# Altium schematic sheet PWR.SchDoc
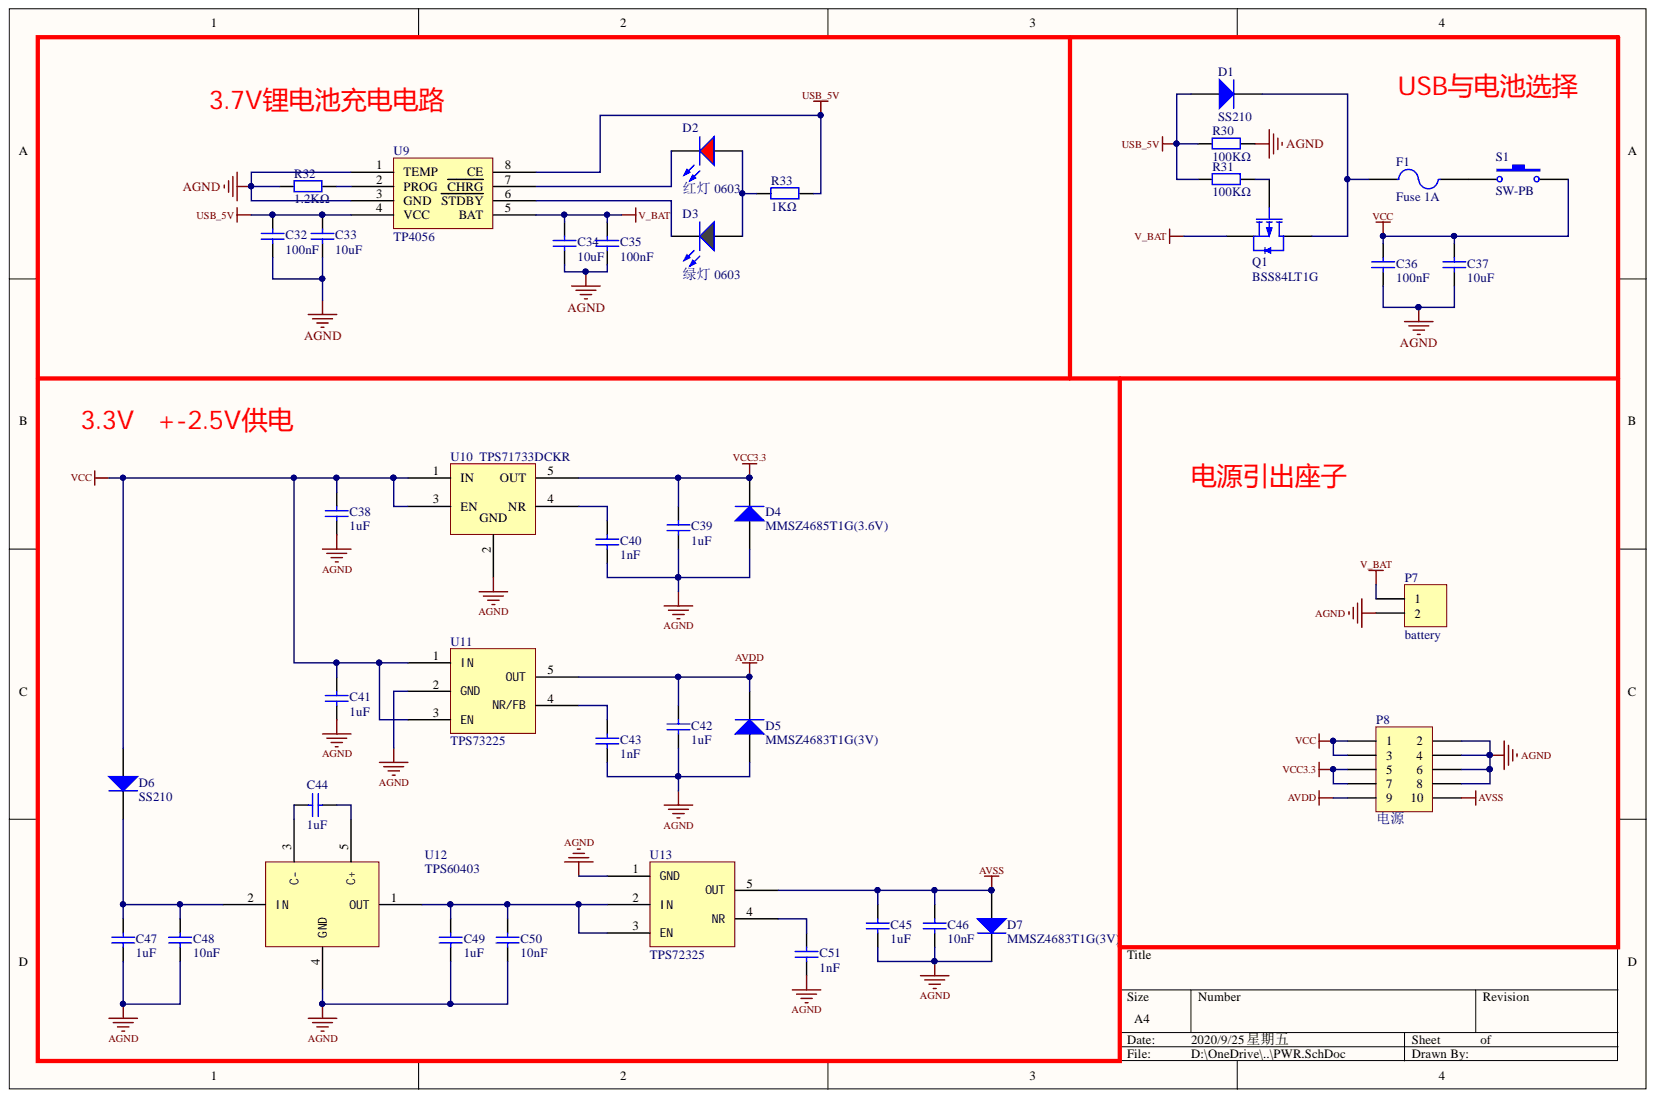
[diagram p0: PWR.SchDoc]

## Parts
C32  100nF  [0402_C]
C33  10uF  [0402_C]
C34  10uF  [0402_C]
C35  100nF  [0402_C]
C36  100nF  [0402_C]
C37  10uF  [0402_C]
C38  1uF  [0402_C]
C39  1uF  [0402_C]
C40  1nF  [0402_C]
C41  1uF  [0402_C]
C42  1uF  [0402_C]
C43  1nF  [0402_C]
C44  1uF  [0402_C]
C45  1uF  [0402_C]
C46  10nF  [0402_C]
C47  1uF  [0402_C]
C48  10nF  [0402_C]
C49  1uF  [0402_C]
C50  10nF  [0402_C]
C51  1nF  [0402_C]
D1  SS210  [SMA(DO-214AC)_S1]
D2  红灯 0603  Package: 0603  [0603_LED_S1]
D3  绿灯 0603  Package: 0603  [0603_LED_S1]
D4  MMSZ4685T1G(3.6V)  [SOD-123]
D5  MMSZ4683T1G(3V)  [SOD-123]
D6  SS210  [SMA(DO-214AC)_S1]
D7  MMSZ4683T1G(3V)  [SOD-123]
F1  Fuse 1A  [0805_R]
P7  battery  [HDR1X2]
P8  电源  [HDR2X5]
Q1  BSS84LT1G  [SOT-23(SOT-23-3)]
R30  100KΩ  [0402_R]
R31  100KΩ  [0402_R]
R32  1.2KΩ  [0402_R]
R33  1KΩ  [0402_R]
S1  SW-PB  [KEY_5.8*5.8]
U9  TP4056  [SOP-8_EP_150mil]
U10  TPS71733DCKR  [SC-70-5]
U11  TPS73225  [SOT-23-5]
U12  TPS60403  [SOT-23-5]
U13  TPS72325  [SOT-23-5]

## Nets
AGND: C32.1 C33.1 C34.1 C35.1 C36.1 C37.1 C38.1 C39.1 C40.1 C41.1 C42.1 C43.1 C45.2 C46.1 C47.2 C48.1 C49.2 C50.1 C51.1 D4.1 D5.1 D7.2 P7.2 P8.2 P8.4 P8.6 P8.8 R30.2 R32.1 U9.1(TEMP) U9.3(GND) U10.2 U11.2(GND) U12.4(GND) U13.1(GND)
AVDD: C42.2 D5.2 P8.9 U11.5(OUT)
AVSS: C45.1 C46.2 D7.1 P8.10 U13.5(OUT)
USB_5V: C32.2 C33.2 D1.1 R30.1 R31.1 R33.2 U9.4(VCC) U9.8(CE)
V_BAT: C34.2 C35.2 P7.1 Q1.2 U9.5(BAT)
VCC: C36.2 C37.2 C38.2 C41.2 D6.1 P8.1 P8.3 S1.2 U10.1(IN) U10.3(EN) U11.1(IN) U11.3(EN)
VCC3.3: C39.2 D4.2 P8.5 P8.7 U10.5(OUT)
NetC40_2: C40.2 U10.4(NR)
NetC43_2: C43.2 U11.4(NR/FB)
NetC44_1: C44.1 U12.5(C+)
NetC44_2: C44.2 U12.3(C-)
NetC47_1: C47.1 C48.2 D6.2 U12.2(IN)
NetC49_1: C49.1 C50.2 U12.1(OUT) U13.2(IN) U13.3(EN)
NetC51_2: C51.2 U13.4(NR)
NetD1_2: D1.2 F1.1 Q1.3
NetD2_A: D2.A D3.A R33.1
NetD2_K: D2.K U9.7(CHRG)
NetD3_K: D3.K U9.6(STDBY)
NetF1_2: F1.2 S1.1
NetQ1_1: Q1.1 R31.2
NetR32_2: R32.2 U9.2(PROG)

## Library data of the parts
C32 C35 C36 = 0402 100nF (104) 10% 16V: 贴片电容; Component Kind: Standard; ComponentLink1Description: 供应商链接; ComponentLink1URL: http://www.szlcsc.com/product/details_1877.html; Library Name: Miscellaneous Devices LC.IntLib; Package: 0402; Supplier: LC; SuppliersPartNumber: C1525
C33 C34 C37 = 0402 10uF (106) 20% 6.3V: 贴片电容; Component Kind: Standard; ComponentLink1Description: 供应商链接; ComponentLink1URL: http://www.szlcsc.com/product/details_16204.html; Library Name: Miscellaneous Devices LC.IntLib; Package: 0402; Supplier: LC; SuppliersPartNumber: C15525
C38 C39 C41 C42 C44 C45 C47 C49 = 0603 1uF (105) 10% 50V: 贴片电容; Component Kind: Standard; ComponentLink1Description: 供应商链接; ComponentLink1URL: http://www.szlcsc.com/product/details_16531.html; Library Name: Miscellaneous Devices LC.IntLib; Package: 0603; Supplier: LC; SuppliersPartNumber: C15849
C40 C43 C51 = 0603 1nF (102) 10% 50V: 贴片电容; Component Kind: Standard; ComponentLink1Description: 供应商链接; ComponentLink1URL: http://www.szlcsc.com/product/details_1940.html; Library Name: Miscellaneous Devices LC.IntLib; Package: 0603; Supplier: LC; SuppliersPartNumber: C1588
C46 C48 C50 = 0603 10nF (103) 10% 50V: 贴片电容; Component Kind: Standard; ComponentLink1Description: 供应商链接; ComponentLink1URL: http://www.szlcsc.com/product/details_58143.html; Library Name: Miscellaneous Devices LC.IntLib; Package: 0603; Supplier: LC; SuppliersPartNumber: C57112
D1 D6 = SMA(DO-214AC) SS210: 二极管; Component Kind: Standard; ComponentLink1Description: 供应商链接; ComponentLink1URL: http://www.szlcsc.com/product/details_15669.html; Library Name: Miscellaneous Devices LC.IntLib; Package: SMA(DO-214AC); Supplier: LC; SuppliersPartNumber: C14996
D2 = 0603 红灯: 发光二极管; Component Kind: Standard; ComponentLink1Description: 供应商链接; ComponentLink1URL: http://www.szlcsc.com/product/details_2659.html; Library Name: Miscellaneous Devices LC.IntLib; Supplier: LC; SuppliersPartNumber: C2286
D3 = 0603 绿灯: 发光二极管; Component Kind: Standard; ComponentLink1Description: 供应商链接; ComponentLink1URL: http://www.szlcsc.com/product/details_73152.html; Library Name: Miscellaneous Devices LC.IntLib; Supplier: LC; SuppliersPartNumber: C72043
D4 D5 D7 = SOD-123 B5819W  SL: 肖特基二极管; Component Kind: Standard; ComponentLink1Description: 供应商链接; ComponentLink1URL: http://www.szlcsc.com/product/details_9093.html; Library Name: Miscellaneous Devices LC.IntLib; Package: SOD-123; Supplier: LC; SuppliersPartNumber: C8598
F1 = Fuse 2: Fuse; Component Kind: Standard; LatestRevisionDate: 17-Jul-2002; LatestRevisionNote: Re-released for DXP Platform.; Library Name: Miscellaneous Devices.IntLib; PackageDescription: Thru-Hole; 2 Leads; PackageReference: PIN-W2/E2.8; Published: 8-Jun-2000; Simulation: FUSE; Publisher: Altium Limited
P7 = Header 2: Header, 2-Pin; Component Kind: Standard; LatestRevisionDate: 17-Jul-2002; LatestRevisionNote: Re-released for DXP Platform.; Library Name: Miscellaneous Connectors.IntLib; Signal Integrity: Connector; Publisher: Altium Limited
P8 = Header 5X2: Header, 5-Pin, Dual row; Component Kind: Standard; LatestRevisionDate: 17-Jul-2002; LatestRevisionNote: Re-released for DXP Platform.; Library Name: Miscellaneous Connectors.IntLib; Signal Integrity: Connector; Publisher: Altium Limited
Q1 = BSS84LT1G: MOS(场效应管); Component Kind: Standard; ComponentLink1Description: 供应商链接; ComponentLink1URL: http://www.szlcsc.com/product/details_8986.html; Library Name: Miscellaneous Devices LC.IntLib; Package: SOT-23(SOT-23-3); Supplier: LC; SuppliersPartNumber: C8492
R30 R31 = 0402 100KΩ (1003) 1%: 贴片电阻; Component Kind: Standard; ComponentLink1Description: 供应商链接; ComponentLink1URL: http://www.szlcsc.com/product/details_26484.html; Library Name: Miscellaneous Devices LC.IntLib; Package: 0402; Supplier: LC; SuppliersPartNumber: C25741
R32 = 0402 1.2KΩ (1201) 1%: 贴片电阻; Component Kind: Standard; ComponentLink1Description: 供应商链接; ComponentLink1URL: http://www.szlcsc.com/product/details_26605.html; Library Name: Miscellaneous Devices LC.IntLib; Package: 0402; Supplier: LC; SuppliersPartNumber: C25862
R33 = 0402 1KΩ (1001) 1%: 贴片电阻; Component Kind: Standard; ComponentLink1Description: 供应商链接; ComponentLink1URL: http://www.szlcsc.com/product/details_12256.html; Library Name: Miscellaneous Devices LC.IntLib; Package: 0402; Supplier: LC; SuppliersPartNumber: C11702
S1 = SW-PB: Switch; Component Kind: Standard; LatestRevisionDate: 17-Jul-2002; LatestRevisionNote: Re-released for DXP Platform.; Library Name: Miscellaneous Devices.IntLib; PackageDescription: Switch; 2 Leads; PackageReference: SPST-2; Published: 24-Mar-1999; Publisher: Altium Limited
U9 = TP4056_[C16581]: 电池电源管理; Component Kind: Standard; ComponentLink1Description: 供应商链接; ComponentLink1URL: http://www.szlcsc.com/product/details_17264.html; Library Name: Miscellaneous Devices LC.IntLib; Package: SOP-8_EP_150mil; Supplier: LC; SuppliersPartNumber: C16581
U10 = TPS71733DCKR: Component Kind: Standard; Library Name: EEG.SchLib
U11 = TPS73225: Component Kind: Standard; Library Name: EEG.SchLib
U12 = TPS60403: Component Kind: Standard; Library Name: EEG.SchLib
U13 = TPS72325: Component Kind: Standard; Library Name: EEG.SchLib
